# Altium schematic sheet Sheet2.SchDoc(Sheet2)
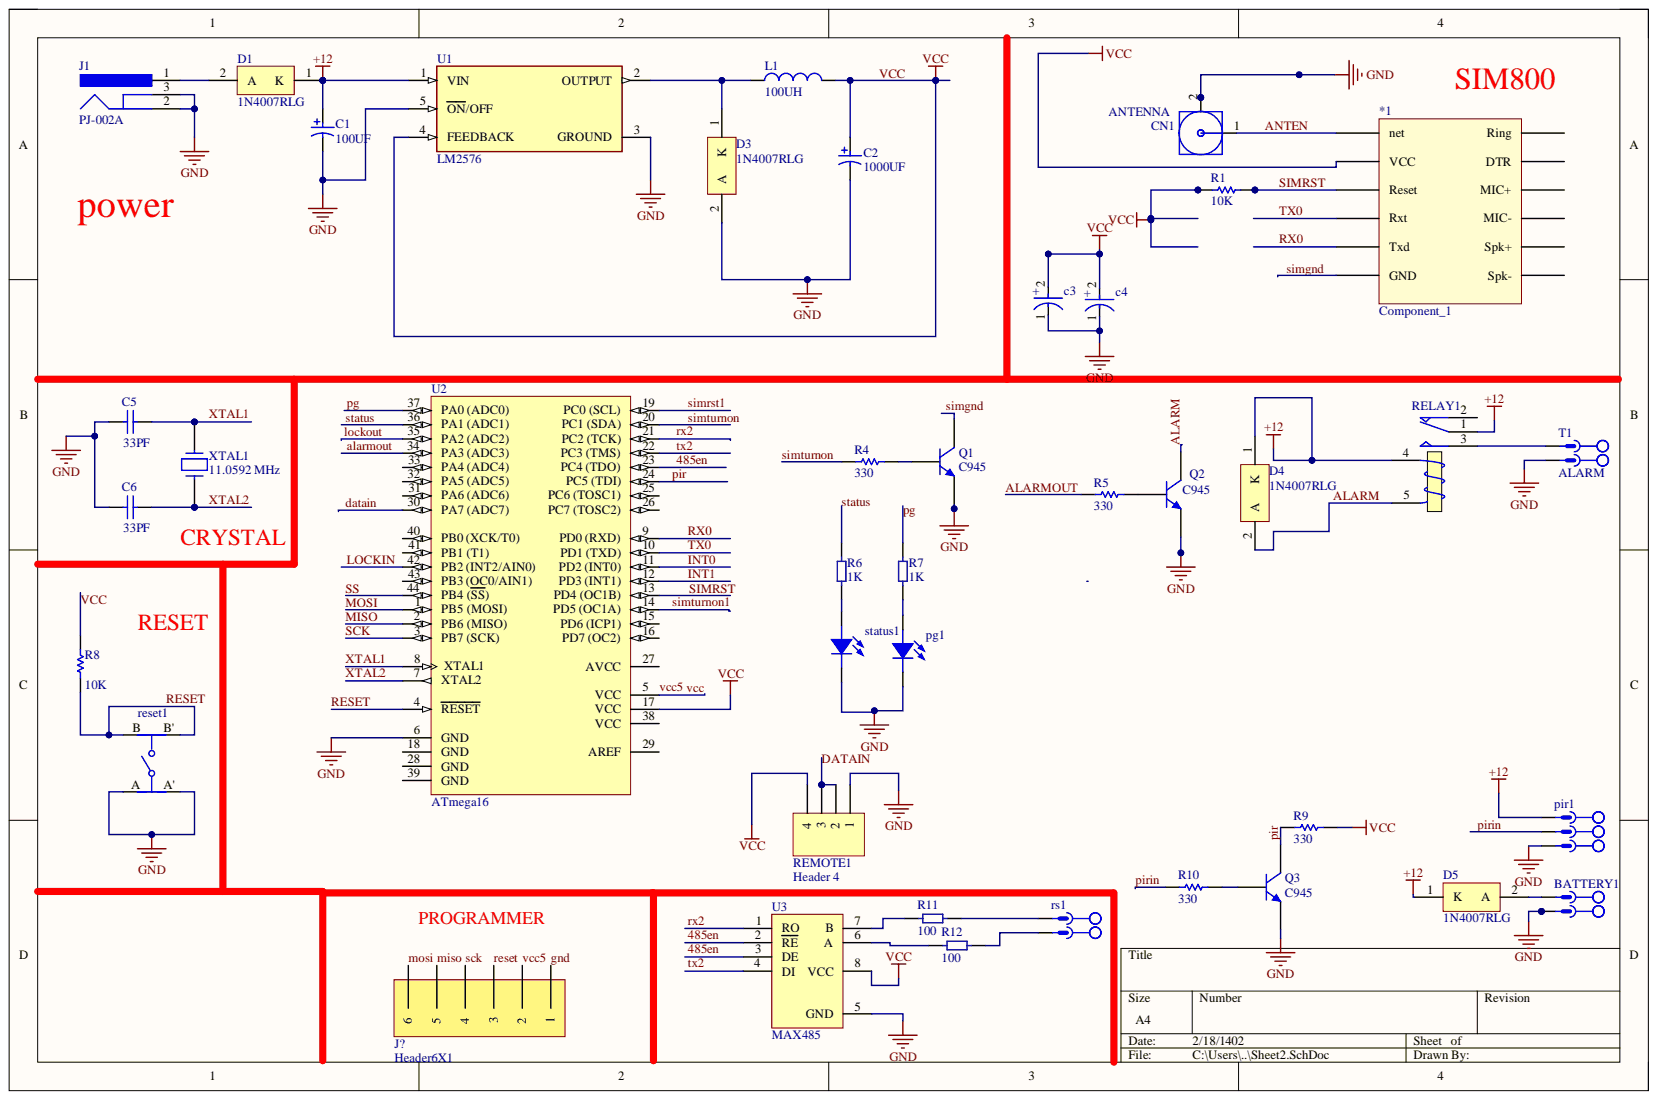
[diagram p0: Sheet2.SchDoc]

## Parts
*1  Component_1  [PCBComponent_1]
BATTERY1  PHOENIX  [MSTB 2,5/2-G-5,08]  (value not drawn)
C1  100UF  [CAPPR65-350-1150X800X1250]
C2  1000UF  [CAPPR65-350-1150X800X1250]
c3  ECA1CM472  [FP-RAD-TH-D_16_0_5-L_25_2-MFG]  (value not drawn)
c4  ECA1CM472  [FP-RAD-TH-D_16_0_5-L_25_2-MFG]  (value not drawn)
C5  33PF  [RB.1/.3]
C6  33PF  [RB.1/.3]
CN1  ANTENNA  [SMA-Right]
D1  1N4007RLG  [ONSC-AXIAL_LEAD-2-59-10_P]
D3  1N4007RLG  [ONSC-AXIAL_LEAD-2-59-10_P]
D4  1N4007RLG  [ONSC-AXIAL_LEAD-2-59-10_P]
D5  1N4007RLG  [ONSC-AXIAL_LEAD-2-59-10_P]
J1  PJ-002A  [CUI-PJ-002A_V]
J?  Header6X1  [HEADER 6X1]
L1  100UH  [INDR62-500-1100X850X1100]
pg1  LED3MM  [LED3mm_GRN]  (value not drawn)
pir1  PHOENIX  [MSTB 2,5/3-G-5,08]  (value not drawn)
Q1  C945  [c945]
Q2  C945  [c945]
Q3  C945  [c945]
R1  value 10K  [AXIAL-0.3]  (hidden comment: Res1)
R4  value 330  [AXIAL-0.3]  (hidden comment: Res1)
R5  value 330  [AXIAL-0.3]  (hidden comment: Res1)
R6  Resistor SMD  [1206 Resistor]  (value not drawn)
R7  Resistor SMD  [1206 Resistor]  (value not drawn)
R8  value 10K  [AXIAL-0.3]  (hidden comment: Res1)
R9  value 330  [AXIAL-0.3]  (hidden comment: Res1)
R10  value 330  [AXIAL-0.3]  (hidden comment: Res1)
R11  Resistor SMD  [1812 Resistor]  (value not drawn)
R12  Resistor SMD  [1812 Resistor]  (value not drawn)
RELAY1  Relay  [Relay 15.40x12.10x13.10]  (value not drawn)
REMOTE1  Header 4  [HDR1X4]
reset1  EVQ-PAE09K  [FP-EVQ-PAE09K-MFG]  (value not drawn)
rs1  PHOENIX  [MSTB 2,5/2-G-5,08]  (value not drawn)
status1  LED3MM  [LED3mm_GRN]  (value not drawn)
T1  ALARM  [MSTB 2,5/2-G-5,08]
U1  LM2576  [FP-KC0005A-MFG]
U2  ATmega16  [44A_M]
U3  MAX485  [SOIC-8]
XTAL1  11.0592 MHz  [My_Crystal]

## Nets
+12: C1.1 D1.1 D4.1 D5.1 pir1.1 RELAY1.1 RELAY1.4 U1.1(VIN)
485en: U2.23(PC4_(TDO)) U3.2(RE) U3.3(DE)
ALARM: D4.2 Q2.3 RELAY1.5
alarmout: R5.2 U2.34(PA3_(ADC3))
ANTEN: *1.0(net) CN1.1
datain: REMOTE1.2 REMOTE1.3 U2.30(PA7_(ADC7))
gnd: BATTERY1.2 C1.2 C2.2 c3.1 c4.1 C5.1 C6.1 CN1.2 D3.2 J1.2 J1.3 J?.1 pg1.2 pir1.3 Q1.2 Q2.2 Q3.2 REMOTE1.1 reset1.A reset1.A' status1.2 T1.2 U1.3(GROUND) U1.5(ON/OFF) U2.6(GND) U3.5(GND)
INT0: U2.11(PD2_(INT0))
INT1: U2.12(PD3_(INT1))
LOCKIN: U2.42(PB2_(INT2/AIN0))
lockout: U2.35(PA2_(ADC2))
miso: J?.5 U2.2(PB6_(MISO))
mosi: J?.6 U2.1(PB5_(MOSI))
pg: R7.2 U2.37(PA0_(ADC0))
pir: Q3.3 R9.2 U2.24(PC5_(TDI))
pirin: pir1.2 R10.2
reset: J?.3 R8.2 reset1.B reset1.B' U2.4(RESET)
RX0: *1.4(Txd) U2.9(PD0_(RXD)) U2.21(PC2_(TCK)) U3.1(RO)  [also labelled rx2]
sck: J?.4 U2.3(PB7_(SCK))
simgnd: *1.5(GND) Q1.3
SIMRST: *1.2(Reset) R1.1 U2.13(PD4_(OC1B))
simrst1: U2.19(PC0_(SCL))
simturnon: R4.2 U2.20(PC1_(SDA))
simturnon1: U2.14(PD5_(OC1A))
SS: U2.44(PB4_(SS))
status: R6.2 U2.36(PA1_(ADC1))
TX0: *1.3(Rxt) U2.10(PD1_(TXD)) U2.22(PC3_(TMS)) U3.4(DI)  [also labelled tx2]
vcc: *1.1(VCC) C2.1 c3.2 c4.2 J?.2 L1.2 R1.2 R8.1 R9.1 REMOTE1.4 U1.4(FEEDBACK) U2.5(VCC) U2.17(VCC) U3.8(VCC)
XTAL1: C5.2 U2.8(XTAL1) XTAL1.2
XTAL2: C6.2 U2.7(XTAL2) XTAL1.1
NetBATTERY1_1: BATTERY1.1 D5.2
NetD1_2: D1.2 J1.1
NetD3_1: D3.1 L1.1 U1.2(OUTPUT)
Netpg1_1: pg1.1 R7.1
NetQ1_1: Q1.1 R4.1
NetQ2_1: Q2.1 R5.1
NetQ3_1: Q3.1 R10.1
NetR6_1: R6.1 status1.1
NetR11_1: R11.1 U3.7(B)
NetR11_2: R11.2 rs1.1
NetR12_1: R12.1 U3.6(A)
NetR12_2: R12.2 rs1.2
NetRELAY1_3: RELAY1.3 T1.1

Not connected: *1 6(Ring) 7(DTR) 8(MIC+) 9(MIC-) 10(Spk+) 11(Spk-); RELAY1 2; U2 15(PD6_(ICP1)) 16(PD7_(OC2)) 18(GND) 25(PC6_(TOSC1)) 26(PC7_(TOSC2)) 27(AVCC) 28(GND) 29(AREF) 31(PA6_(ADC6)) 32(PA5_(ADC5)) 33(PA4_(ADC4)) 38(VCC) 39(GND) 40(PB0_(XCK/T0)) 41(PB1_(T1)) 43(PB3_(OC0/AIN1))

## Library data of the parts
BATTERY1 rs1 T1 = CON_Phoenix_MSTB_02: 2 Pitch: 5.08 mm Color: green Contact surface: Tin Assembly; Manufacturer: PHOENIX CONTACT; Connector type: PCB Terminal Block; designer: ABBAS BASEREH; Max Current: 12 A; Positions: 2; Voltage: 320 V; Category: Connector; Component Kind: Standard; ComponentLink1Description: Header, Nominal current: 12 A Rated voltage (III/2): 320 V Number of positions: 2 Pitch: 5.08 mm Color: green Contact surface: Tin Assembly: Soldering  DESIGNER:ABBAS BASEREH; ComponentLink2Description: Reference; Library Name: Altium.IntLib; Mounting Technology: Through-Hole; PART.NO: PHOENIX; Pin Count: 2; Revision 1: 2017-3-1; Signal Integrity: CON-2P; Status: Unreleased
C1 = CMP-1665-00042-2: Aluminum Electrolytic Capacitor, 100 uF, +/- 20%, 50 V, -40 to 85 degC, 2-Pin THD, RoHS, Bulk; Manufacturer: Panasonic; Dielectric Material: Aluminium; Dissipation Factor: 0.12%; Lead Diameter: 0.6mm; Lead/Base Style: Straight; Leakage Current: 3uA; Life (Hours): 2000Hour; Polarity: Polar; Ripple Current: 250mA; Voltage: 50V; Capacitance: 100uF; Case/Package: Radial; Component Kind: Standard; Datasheet URL: https://industrial.panasonic.com/cdbs/www-data/pdf/RDF0000/ABA0000C1218.pdf; Datasheet Version: Rev. 05, 10/2016; Diameter: 8mm; Height: 11.5mm; Height - Seated (Max): 0.492inch; Lead Free: LeadFree; Lead Pitch: 3.5mm; Length: 11.5mm; Library Name: Altium Content Vault; Manufacturer URL: http://www.panasonic.com/; Max Operating Temperature: 85degC; Min Operating Temperature: -40degC; Mount: ThroughHole; Number of Pins: 2; Package Description: 2-Pin Radial Lead, Dia 8 mm, Height 12.5 mm; Package Quantity: 200; Package Reference: CAPPR65-350-1150X800X1250; Packaging: Bulk; Radiation Hardening: No; REACH SVHC: NoSVHC; Ripple Current (AC): 250mA; RoHSCompliant: true; Termination: Radial; Tolerance: 20%; Voltage Rating: 50V; Voltage Rating (DC): 50V
C2 = CMP-1665-00042-2: Aluminum Electrolytic Capacitor, 100 uF, +/- 20%, 50 V, -40 to 85 degC, 2-Pin THD, RoHS, Bulk; Manufacturer: Panasonic; Capacitance: 100uF; Dielectric Material: Aluminium; Dissipation Factor: 0.12%; Lead Diameter: 0.6mm; Lead/Base Style: Straight; Leakage Current: 3uA; Life (Hours): 2000Hour; Polarity: Polar; Ripple Current: 250mA; Voltage: 50V; Case/Package: Radial; Component Kind: Standard; Datasheet URL: https://industrial.panasonic.com/cdbs/www-data/pdf/RDF0000/ABA0000C1218.pdf; Datasheet Version: Rev. 05, 10/2016; Diameter: 8mm; Height: 11.5mm; Height - Seated (Max): 0.492inch; Lead Free: LeadFree; Lead Pitch: 3.5mm; Length: 11.5mm; Library Name: Altium Content Vault; Manufacturer URL: http://www.panasonic.com/; Max Operating Temperature: 85degC; Min Operating Temperature: -40degC; Mount: ThroughHole; Number of Pins: 2; Package Description: 2-Pin Radial Lead, Dia 8 mm, Height 12.5 mm; Package Quantity: 200; Package Reference: CAPPR65-350-1150X800X1250; Packaging: Bulk; Radiation Hardening: No; REACH SVHC: NoSVHC; Ripple Current (AC): 250mA; RoHSCompliant: true; Termination: Radial; Tolerance: 20%; Voltage Rating: 50V; Voltage Rating (DC): 50V
c3 c4 = CMP-05427-001624-1: Aluminum Electrolytic Capacitors (Radial Lead Type) 4700uF ±20% 16V; Capacitance: 4.7mF; Dielectric Material: Aluminium; Dissipation Factor: 0.26%; Lead Diameter: 0.8mm; Lead/Base Style: Straight; Leakage Current: 3uA; Life (Hours): 2000Hour; Polarity: Polar; Ripple Current: 1.36A; Case/Package: Radial; Component Kind: Standard; Construction: RADIAL; Diameter: 16mm; Height: 25mm; Height - Seated (Max): 1.063inch; Lead Free: Lead Free; Lead Pitch: 7.5mm; Length: 25mm; Library Name: Altium Content Vault; Max Operating Temperature: 85°C; Min Operating Temperature: -40°C; Mount: Through Hole; Number of Pins: 2; Package Quantity: 100; Packaging: Bulk; Radiation Hardening: No; REACH SVHC: No SVHC; RoHS Compliant: Yes; Series: M; Termination: Radial; Tolerance: 20%; Voltage: 16V; Voltage Rating: 16V; Voltage Rating (DC): 16V
C5 C6 = Cap Semi: Capacitor (Semiconductor SIM Model); Component Kind: Standard; LatestRevisionDate: 29-May-2009; LatestRevisionNote: IPC-7351 Footprint Added.; Library Name: Devices.IntLib; PackageDescription: Chip Capacitor; PackageReference: C1206; Published: 8-Jun-2000; Signal Integrity: Cap Semi; Simulation: CAP; Value: 33PF; Publisher: Altium Limited
D1 D3-D5 = CMP-0902-00147-1: Standard Recovery Rectifier, 2-Pin Axial_Lead, Pb-Free, Tape and Reel; Manufacturer: On Semiconductor; IFSM Max (A): 30; IO(rec) Max (A): 1; VRRM Max (V): 1000; Component Kind: Standard; ComponentLink1Description: Manufacturer URL; ComponentLink1URL: http://www.onsemi.com/; ComponentLink2Description: Datasheet; ComponentLink2URL: http://www.onsemi.com/pub_link/Collateral/1N4001-D.PDF; ComponentLink3Description: Package Specification; ComponentLink3URL: http://www.onsemi.cn/pub_link/Collateral/59-10.PDF; DatasheetVersion: Rev. 13; Library Name: Altium Content Vault; Mounting Technology: Through Hole; PackageDescription: 2-Pin, Axial Lead, Diameter 2.35 mm; PackageReference: AXIAL_LEAD-2-59-10; PackageVersion: Rev. U, 02/2005; Packing: Tape and Reel; PartNumber: 1N4007RLG; RoHS: TRUE; Type: Standard Recovery
J1 = CMP-2000-06895-2: Through Hole Right Angle DC Power Jack, 2.5 A, 2 mm Center Pin, 3 Position, -25 to 85 degC, RoHS, Bulk; Manufacturer: CUIInc.; Brand: CUI; Current Rating: 2.5A; Inside Contact Diameter: 2mm; Insulation Resistance: 50MOhms; Outside Contact Diameter: 6.5mm; Voltage Rating: 24V; Component Kind: Standard; Connector Type: DCPowerJack; Contact Gender: Male; Contact Material: Copper; Contact Plating: Nickel; Datasheet URL: http://www.cui.com/product/resource/pj-002a.pdf; Datasheet Version: Rev. 1.03, 04/2016; Factory Pack Quantity: 500; Flammability Rating: UL94V-0; Housing Material: PolybutyleneTerephthalate(PBT); Library Name: Altium Content Vault; Manufacturer URL: http://www.cui.com/; Mounting Angle: Right; Mounting Style: ThroughHole; Mounting Technology: ThroughHole; Operating Temperature Range: -25Cto+85C; Package Description: 3-Pin Through Hole Device, Body 14.4 x 9 mm, Height 11 mm; Package Reference: PJ-002A; Product: Jacks; Product Category: DCPowerConnectors; RoHS: True; Termination Style: ThroughHole
L1 = CMP-1747-00013-1: Radial Inductor, 100 uH, +/- 10%, 0.91 A, -55 to 105 degC, 2-Pin THD, RoHS, Bulk; Case\Package: 0603; Colour: Red; Forward Current: 20 mA; Forward Voltage: 1.8 V; Lens Style: Diffused; Luminous Intensity: 17 mcd; Max Power Dissipation: 52 mW; Nominal Wavelength: 639 nm; Power Rating: 78 mW; Viewing Angle: 170 degrees; Case Code (Imperial): 0603; Case Code (Metric): 1608; Component Kind: Standard; ComponentLink1Description: Manufacturer URL; ComponentLink1URL: http://www.bourns.com/; ComponentLink2Description: Datasheet; ComponentLink2URL: http://www.bourns.com/docs/Product-Datasheets/rl622_series.pdf; DatasheetVersion: Aug-10; Height: 0.8 mm; Illumination Colour: Red; Length: 1.6 mm; Library Name: Altium Content Vault; Max Operating Temperature: 85 degC; Min Operating Temperature: -40 degC; Mount: Surface Mount; Nominal Vf: 1.8 V; Package Quantity: 4000; PackageDescription: 2-Pin Radial Lead, Dia 8.5 mm, Pitch 5 mm; PackageReference: INDR62-500-1100X850X1100; Packaging: Tape and Reel; Pins: 2; Radiation Hardening: No; REACH SVHC: No SVHC; RoHSCompliant: true; Width: 0.8 mm
pg1 status1 = LED 3MM: Light Emitting Diode; Designer: Ali Hafizi; Component Kind: Standard; Library Name: Altium.IntLib
pir1 = CON_Phoenix_MSTB_03: 3 Pitch: 5.08 mm Color: green Contact surface: Tin Assembly; Manufacturer: PHOENIX CONTACT; Connector type: PCB Terminal Block; designer: ABBAS BASEREH; Max Current: 12 A; Positions: 3; Voltage: 320 V; Category: Connector; Component Kind: Standard; ComponentLink1Description: Header, Nominal current: 12 A Rated voltage (III/2): 320 V Number of positions: 3 Pitch: 5.08 mm Color: green Contact surface: Tin Assembly: Soldering  DESIGNER:ABBAS BASEREH; ComponentLink2Description: Reference; Library Name: Altium.IntLib; Mounting Technology: Through-Hole; PART.NO: PHOENIX; Pin Count: 3; Revision 1: 2017-3-1; Signal Integrity: CON-3P; Status: Unreleased
Q1-Q3 = C945: NPN Silicon Epitaxial Planar Transistor; Component Kind: Standard; ComponentLink1Description: Manufacturer Link; ComponentLink1URL: http://www.taitroncomponents.com/; ComponentLink2Description: Datasheet; ComponentLink2URL: http://www.taitroncomponents.com/; DatasheetVersion: 2017; LatestRevisionDate: 2017; LatestRevisionNote: IPC-7351 Footprint Added.; Library Name: Altium.IntLib; PackageDescription: 3-Pin SOT-23 Package 0.95 mm Pitch, 2.3 mm Lead Span; PackageReference: SOT23; PackageVersion: 2017-2-8; Published: 2017-2-8; Publisher: Abbas Basereh
R1 R4 R5 R8-R10 = Res1: Resistor; Component Kind: Standard; LatestRevisionDate: 17-Jul-2002; LatestRevisionNote: Re-released for DXP Platform.; Library Name: Miscellaneous Devices.IntLib; PackageDescription: Axial Device, Thru-Hole; 2 Leads; 0.3 in Pin Spacing; PackageReference: AXIAL-0.3; Published: 8-Jun-2000; Signal Integrity: Res; Simulation: RESISTOR; Publisher: Altium Limited
RELAY1 = RELAY General: Relay_SPDT POWER NEEDLE; Company: E.P.I.L; Designer: Ali Hafizi; Component Kind: Standard; Design_Date: 2011/10/31; Library Name: Altium.IntLib
REMOTE1 = Header 4: Header, 4-Pin; Component Kind: Standard; LatestRevisionDate: 17-Jul-2002; LatestRevisionNote: Re-released for DXP Platform.; Library Name: Altium.IntLib; Signal Integrity: Connector; Publisher: Altium Limited
reset1 = CMP-05618-000085-1: SWITCH TACTILE SPST-NO 0.02A 15V; Actuator Color: Black; Actuator Length: 5.6mm; Actuator Type: Standard; Contact Current Rating: 20mA; Contact Resistance: 50mR; Electrical Life: 100000Cycles; Illumination Type: Non-Illuminated; Operating Force: 1.6N; Switch Function: Off-Mom; Switch Travel: 0.25mm; Throw Configuration: SPST; Color: Black; Component Kind: Standard; Contact Plating: Gold; Current Rating: 20mA; Depth: 7.8mm; Height: 9.5mm; Lead Free: Lead Free; Length: 6mm; Library Name: Altium Content Vault; Max Current Rating: 20mA; Max Operating Temperature: 70°C; Max Voltage Rating (DC): 15V; Mechanical Life: 100000Cycles; Min Operating Temperature: -20°C; Mount: Through Hole; Orientation: Straight; Package Quantity: 500; Packaging: Bulk; Radiation Hardening: No; RoHS Compliant: Yes; Series: EVQPA; Size: 6mm; Termination: PC Pin; Voltage Rating (DC): 15V; Width: 6mm
U1 = CMP-0069-01993-4: IC REG BUCK ADJ 3A TO220-5; Manufacturer: Texas Instruments; Manufacturer Part Number: LM2576HVT-ADJ/NOPB; Max Duty Cycle: 98%; Max Junction Temp: +150°C; Max Supply Voltage: 60V; Nominal Supply Current: 5-50uA; Number Of Outputs: 1; Output Type: AdjustableProgrammable; Output Voltage: 57V; Switching Frequency: 52kHz; Automotive: No; Category: IC; Component Kind: Standard; ComponentLink2Description: Datasheet; ComponentLink2URL: http://www.ti.com/lit/ds/symlink/lm2576hv.pdf; Device Class L1: Integrated Circuits (ICs); Device Class L2: Power Management ICs; Device Class L3: Voltage Regulators - Switching; Digikey Description: IC REG BUCK ADJ 3A TO220-5; Height: 16.51mm; Lead Free: Yes; Library Name: Altium Content Vault; Mouser Description: Switching Voltage Regulators 3A STEP-DOWN VLTG REG; Octopart: https://octopart.com/lm2576hvt-adj%2Fnopb-texas+instruments-24812812; Output Current: 3A; Package: KC0005A; RoHS: TRUE; Switching Topology: Buck; Temperature: -40°C to +125°C; Temperature Range High: +125°C; Temperature Range Low: -40°C
U2 = CMP-0095-00235-2: 8-bit AVR Microcontroller, 4.5-5.5V, 16KB Flash, 512 Bytes EEPROM, 1KB SRAM, 44-pin TQFP, Industrial Grade (-40°C to 85°C), Pb-Free; Code_JEDEC: MS-026-ACB; Component Kind: Standard; ComponentLink1Description: Manufacturer URL; ComponentLink1URL: http://www.atmel.com/; ComponentLink2Description: ATmega16(L)  Datasheet; ComponentLink2URL: http://www.atmel.com/dyn/resources/prod_documents/doc2466.pdf; ComponentLink3Description: ATmega16(L) Summary; ComponentLink3URL: http://www.atmel.com/dyn/resources/prod_documents/2466S.pdf; DatasheetVersion: revT, Jul-2010; Library Name: Altium Content Vault; PackageDescription: 44-Pin Plastic Thin Profile Quad Flat Pack, 10 x 10 x 1.0 mm Body, 0.8 mm Pitch (TQFP); PackageReference: 44A; PackageVersion: revC, Oct-2010; RevisionNote: DatasheetVersion & PackageVersion updated. URLs added.
XTAL1 = CRYSTAL: Component Kind: Standard; Description: Crystal; Library Name: Devices.IntLib
*1 = Component_1: Component Kind: Standard; Library Name: sim800L.IntLib
CN1 = ANTENNA: Component Kind: Standard; Library Name: ZetaBoard Altium Lib 14000319.IntLib
J? = Header6X1: Component Kind: Standard; Library Name: AltiumLib1.IntLib
R6 R7 R11 R12 = Resistor SMD: Component Kind: Standard; Library Name: ZetaBoard Altium Lib 14000319.IntLib
U3 = MAX485: Component Kind: Standard; Library Name: ZetaBoard Altium Lib 14000319.IntLib
